# Altium schematic sheet 2.WIZ750SR_EVB_CON.SchDoc
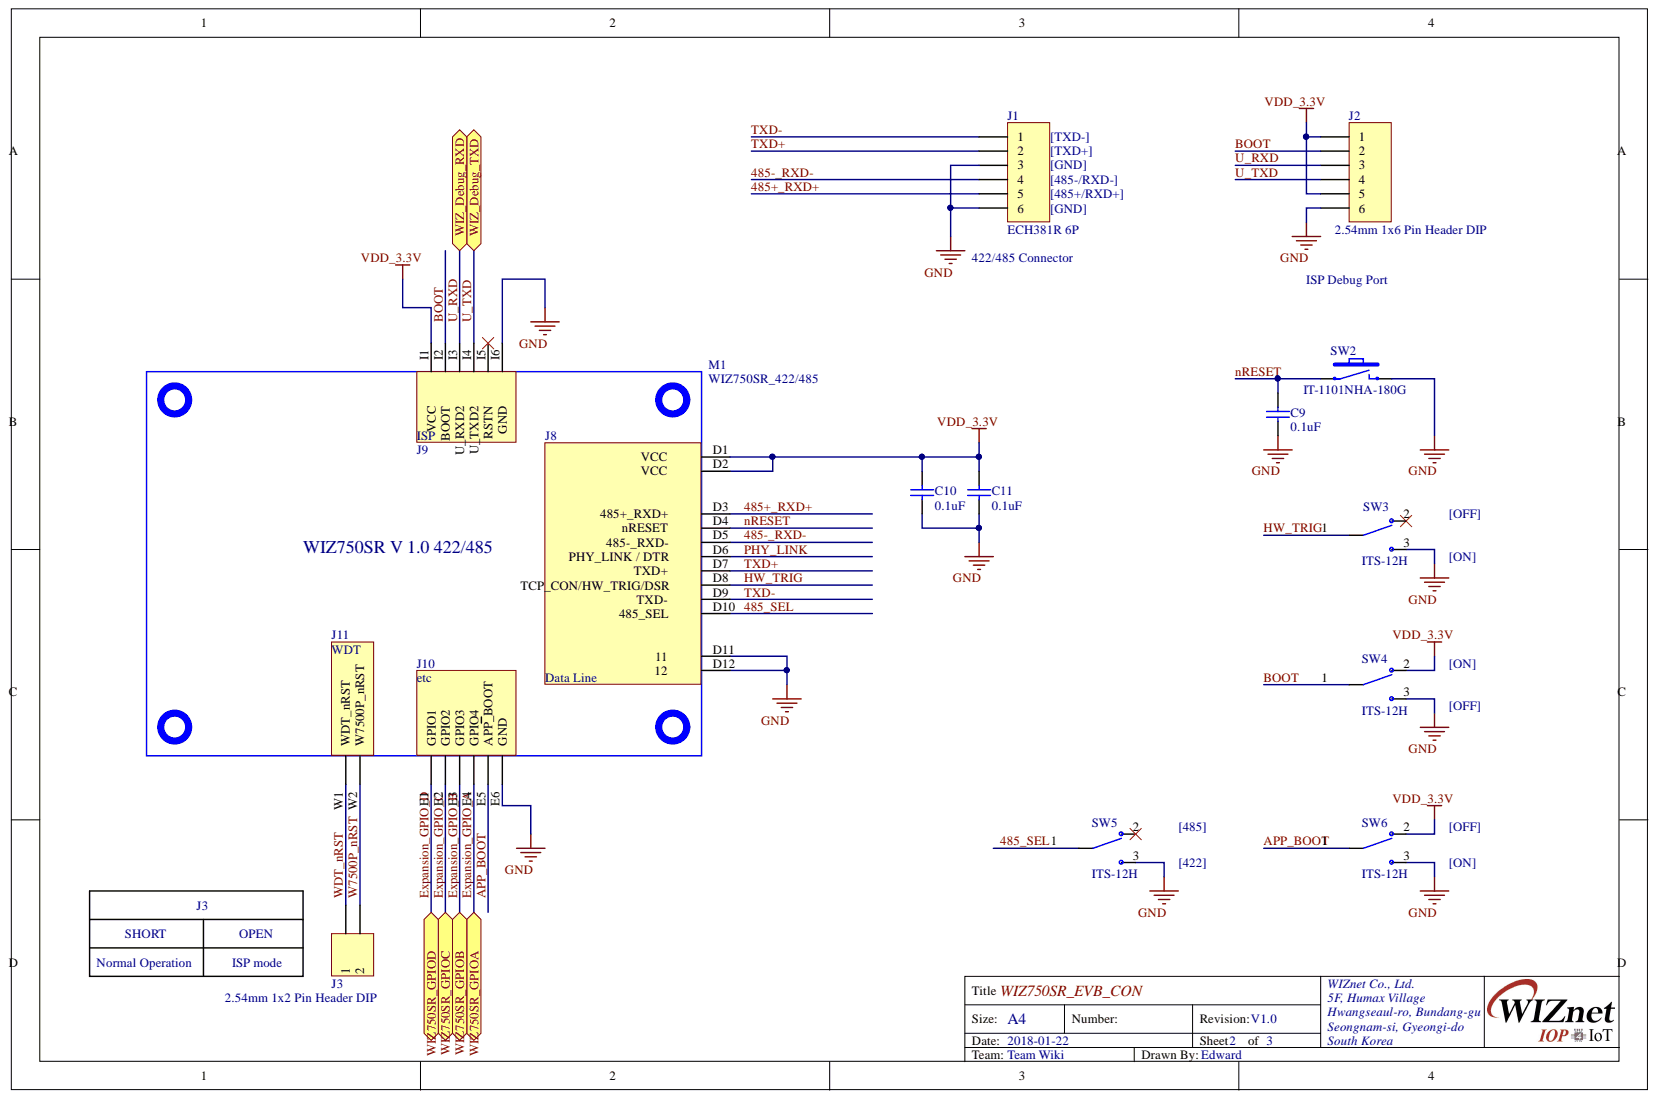
[diagram p0: 2.WIZ750SR_EVB_CON.SchDoc]

## Parts
C9  0.1uF  [CAP-0603]
C10  0.1uF  [CAP-0603]
C11  0.1uF  [CAP-0603]
J1  ECH381R 6P  [ECH381R_6P]
J2  2.54mm 1x6 Pin Header DIP  [IDC-1X6]
J3  2.54mm 1x2 Pin Header DIP  [IDC-1X2]
M1  WIZ750SR_422/485  [WIZ750SR_V1.0_232/485]
SW2  IT-1101NHA-180G  [IT-1101NHA]
SW3  ITS-12H  [ITS-12H]
SW4  ITS-12H  [ITS-12H]
SW5  ITS-12H  [ITS-12H]
SW6  ITS-12H  [ITS-12H]

## Ports
WIZ750SR_GPIOA, WIZ750SR_GPIOB, WIZ750SR_GPIOC, WIZ750SR_GPIOD, WIZ_DEBUG_RXD, WIZ_DEBUG_TXD

## Nets
GND: C9.1 C10.1 C11.1 J1.3 J1.6 J2.6 M1.D11 M1.D12 M1.E6(GND) M1.I6 SW2.2 SW3.3 SW4.3 SW5.3 SW6.3
VDD_3.3V: C10.2 C11.2 J2.1 J2.5 M1.D1 M1.D2 M1.I1 SW4.2 SW6.2
485+_RXD+: J1.5 M1.D3
485-_RXD-: J1.4 M1.D5
485_SEL: M1.D10 SW5.1
APP_BOOT: M1.E5(APP_BOOT) SW6.1
BOOT: J2.2 M1.I2 SW4.1
EXPANSION_GPIO_A: M1.E4(GPIO4) ; port WIZ750SR_GPIOA
EXPANSION_GPIO_B: M1.E3(GPIO3) ; port WIZ750SR_GPIOB
EXPANSION_GPIO_C: M1.E2(GPIO2) ; port WIZ750SR_GPIOC
EXPANSION_GPIO_D: M1.E1(GPIO1) ; port WIZ750SR_GPIOD
HW_TRIG: M1.D8 SW3.1
NRESET: C9.2 M1.D4 SW2.1
PHY_LINK: M1.D6
TXD+: J1.2 M1.D7
TXD-: J1.1 M1.D9
U_RXD: J2.3 M1.I3 ; port WIZ_DEBUG_RXD
U_TXD: J2.4 M1.I4 ; port WIZ_DEBUG_TXD
W7500P_NRST: J3.2 M1.W2(W7500P_nRST)
WDT_NRST: J3.1 M1.W1(WDT_nRST)

Not connected: M1 I5; SW3 2; SW5 2

## Library data of the parts
C9-C11 = CAP-0603: Ceramic CAP 0603(1608); Component Kind: Standard; Library Name: Scott_lib.IntLib
J1 = ECH381R 6P: Headers(Sockets) for pluggable terminal blocks; Component Kind: Standard; Library Name: Edward.IntLib
J2 = Header 6: Header, 6-Pin; Component Kind: Standard; LatestRevisionDate: 17-Jul-2002; LatestRevisionNote: Re-released for DXP Platform.; Signal Integrity: Connector; Library Name: Edward.IntLib; Publisher: Altium Limited
J3 = Header 2: Header, 2-Pin; Component Kind: Standard; LatestRevisionDate: 17-Jul-2002; LatestRevisionNote: Re-released for DXP Platform.; Signal Integrity: Connector; Library Name: Edward.IntLib; Publisher: Altium Limited
M1 = WIZ750SR_V1.0_422/485: Serial to ethernet module, 45mmx30mm, 422/485; Component Kind: Standard; Library Name: Edward.IntLib
SW2 = IT-1101NHA-180G: TACT Switch (SMD); Component Kind: Standard; Library Name: Scott_lib.IntLib
SW3-SW6 = ITS-12H: Slide Switch; Component Kind: Standard; Library Name: Scott_lib.IntLib
